# Altium schematic sheet MCU_RF.SchDoc
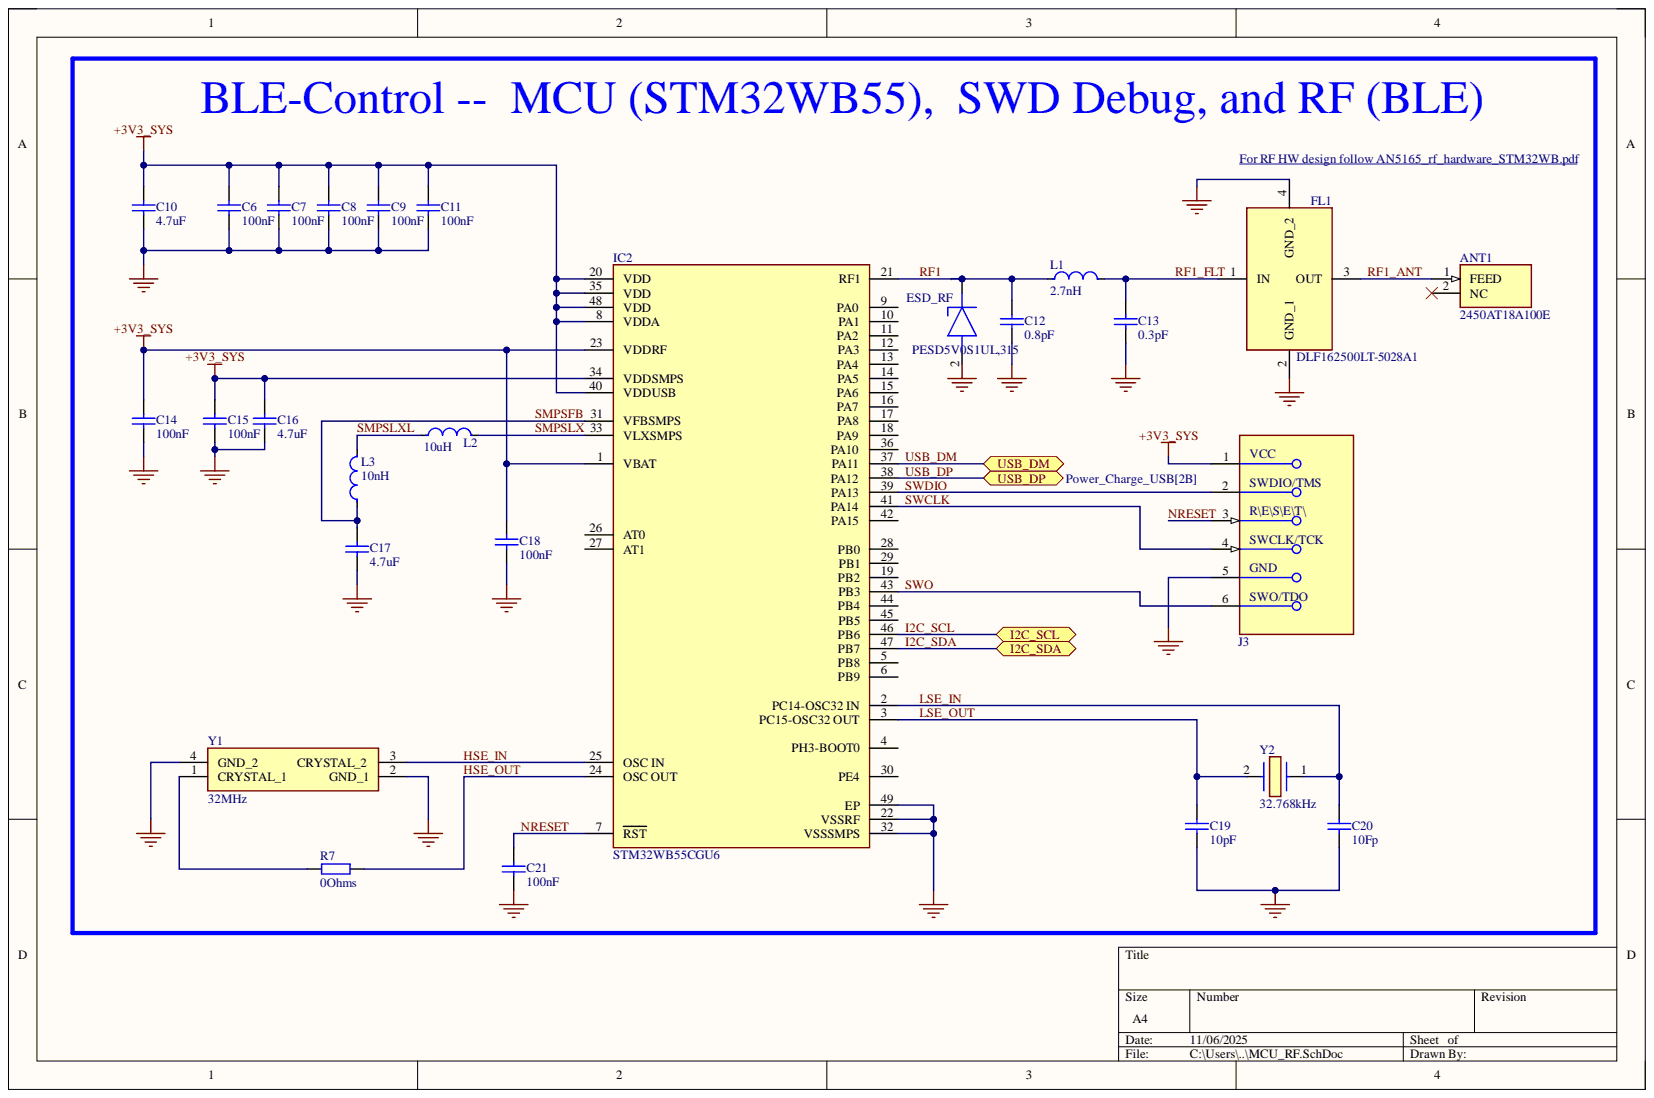
[diagram p0: MCU_RF.SchDoc]

## Parts
ANT1  2450AT18A100E  [ANTC3216X140N]
C6  100nF  [CAP_0402]
C7  100nF  [CAP_0402]
C8  100nF  [CAP_0402]
C9  100nF  [CAP_0402]
C10  4.7uF  [CAP_0402]
C11  100nF  [CAP_0402]
C12  0.8pF  [CAP_0402]
C13  0.3pF  [CAP_0402]
C14  100nF  [CAP_0402]
C15  100nF  [CAP_0402]
C16  4.7uF  [CAP_0402]
C17  4.7uF  [CAP_0402]
C18  100nF  [CAP_0402]
C19  10pF  [CAP_0402]
C20  10Fp  [CAP_0402]
C21  100nF  [CAP_0402]
ESD_RF  PESD5V0S1UL,315  [PESD5V0S1BL315]
FL1  DLF162500LT-5028A1  [DLF162500LT5028A1 - duplicate]
IC2  STM32WB55CGU6  [FP-STM32WB55CGU6-IPC_C]
J3  TC2030-CTX-NL  [Tag_Connect_0_MFG]  (value not drawn)
L1  2.7nH  [FP-LQW15AN_00-W0_6-0_1-MFG]
L2  10uH  [FP-LQM21FN_70-IPC_B]
L3  10nH  [FP-LQW15AN_00-W0_6-0_1-MFG]
R7  0Ohms  [RES_0402]
Y1  32MHz  [NX3225SA32MHZEXS00ACS02368]
Y2  32.768kHz  [ABS07]

## Ports
I2C_SCL, I2C_SDA, USB_DM, USB_DP

## Nets
+3V3_SYS: C6.2 C7.2 C8.2 C9.2 C10.2 C11.2 C14.2 C15.2 C16.2 C18.2 IC2.1(VBAT) IC2.8(VDDA) IC2.20(VDD) IC2.23(VDDRF) IC2.34(VDDSMPS) IC2.35(VDD) IC2.40(VDDUSB) IC2.48(VDD) J3.1
GND: C6.1 C7.1 C8.1 C9.1 C10.1 C11.1 C12.1 C13.1 C14.1 C15.1 C16.1 C17.1 C18.1 C19.1 C20.1 C21.1 ESD_RF.2 FL1.2(GND_1) FL1.4(GND_2) IC2.22(VSSRF) IC2.32(VSSSMPS) IC2.49(EP) J3.5 Y1.2(GND_1) Y1.4(GND_2)
HSE_IN: IC2.25(OSC_IN) Y1.3(CRYSTAL_2)
HSE_OUT: IC2.24(OSC_OUT) R7.2
I2C_SCL: IC2.46(PB6) ; port I2C_SCL
I2C_SDA: IC2.47(PB7) ; port I2C_SDA
LSE_IN: C20.2 IC2.2(PC14-OSC32_IN) Y2.1
LSE_OUT: C19.2 IC2.3(PC15-OSC32_OUT) Y2.2
NRESET: C21.2 IC2.7(RST) J3.3
RF1: C12.2 ESD_RF.1 IC2.21(RF1) L1.1
RF1_ANT: ANT1.1 FL1.3(OUT)
RF1_FLT: C13.2 FL1.1(IN) L1.2
SMPSFB: C17.2 IC2.31(VFBSMPS) L3.1
SMPSLX: IC2.33(VLXSMPS) L2.2
SMPSLXL: L2.1 L3.2
SWCLK: IC2.41(PA14) J3.4
SWDIO: IC2.39(PA13) J3.2
SWO: IC2.43(PB3) J3.6
USB_DM: IC2.37(PA11) ; port USB_DM
USB_DP: IC2.38(PA12) ; port USB_DP
NetR7_1: R7.1 Y1.1(CRYSTAL_1)

Not connected: ANT1 2; IC2 4(PH3-BOOT0) 5(PB8) 6(PB9) 9(PA0) 10(PA1) 11(PA2) 12(PA3) 13(PA4) 14(PA5) 15(PA6) 16(PA7) 17(PA8) 18(PA9) 19(PB2) 26(AT0) 27(AT1) 28(PB0) 29(PB1) 30(PE4) 36(PA10) 42(PA15) 44(PB4) 45(PB5)

## Library data of the parts
ANT1 = 2450AT18A100E: Antenna; Manufacturer_Name: JOHANSON TECHNOLOGY; Arrow Part Number: 2450AT18A100E; Arrow Price/Stock: https://www.arrow.com/en/products/2450at18a100e/johanson-dielectrics?utm_currency=USD&region=europe; Component Kind: Standard; Datasheet Link: https://www.johansontechnology.com/datasheets/2450AT18A100/2450AT18A100.pdf; Height: 1.4mm; Manufacturer_Part_Number: 2450AT18A100E; Mouser Part Number: 609-2450AT18A100E; Mouser Price/Stock: https://www.mouser.co.uk/ProductDetail/Johanson-Technology/2450AT18A100E?qs=yCnrNFeXz%252BjzOYKPg7LGCw%3D%3D
C6-C9 C11 C14 C15 C18-C21 = C_0402: Ceramic Chip Capacitor 0402; Dielectric: X7R; ProductionStatus: Placeholder; Tolerance: 10%; Voltage: 10V; Component Kind: Standard; Height: 0.35mm; Library Name: BLE-Control.SchLib; Package: 0402 (1005)
C10 C16 C17 = C_0402: Ceramic Chip Capacitor 0402; Part Number: C1005X5R1E105K; Dielectric: X7R; ProductionStatus: Placeholder; Tolerance: 10%; Voltage: 10V; Component Kind: Standard; Height: 0.35mm; Library Name: BLE-Control.SchLib; Package: 0402 (1005); PartNumber: C1005X5R1E105K
C12 = C_0402: GRM1555C1HR80BA01D; Dielectric: X7R; ProductionStatus: Placeholder; Tolerance: 10%; Voltage: 10V; Component Kind: Standard; Height: 0.35mm; Library Name: BLE-Control.SchLib; Package: 0402 (1005)
C13 = C_0402: GRM1555C1HR30WA01D; Dielectric: X7R; ProductionStatus: Placeholder; Tolerance: 10%; Voltage: 10V; Component Kind: Standard; Height: 0.35mm; Library Name: BLE-Control.SchLib; Package: 0402 (1005)
ESD_RF = PESD5V0S1UL,315: Diode ESD (Uni-directional); Manufacturer_Name: Nexperia; Arrow Part Number: PESD5V0S1UL,315; Arrow Price/Stock: https://www.arrow.com/en/products/pesd5v0s1ul315/nexperia?utm_currency=USD&region=nac; Component Kind: Standard; Datasheet Link: https://assets.nexperia.com/documents/data-sheet/PESD5V0S1UL.pdf; Height: 0mm; Manufacturer_Part_Number: PESD5V0S1UL,315; Mouser Part Number: 771-PESD5V0S1UL315; Mouser Price/Stock: https://www.mouser.co.uk/ProductDetail/Nexperia/PESD5V0S1UL315?qs=LOCUfHb8d9sSC%2FUB7ugsVw%3D%3D
FL1 = DLF162500LT-5028A1: Filter; Manufacturer_Name: TDK; Arrow Part Number: DLF162500LT-5028A1; Arrow Price/Stock: https://www.arrow.com/en/products/dlf162500lt-5028a1/tdk?utm_currency=USD&region=nac; Component Kind: Standard; Datasheet Link: https://product.tdk.com/system/files/dam/doc/product/rf/rf/filter/catalog/rf_lpf_dlf162500lt-5028a1_en.pdf; Height: 0.4mm; Library Name: BLE-Control.SchLib; Manufacturer_Part_Number: DLF162500LT-5028A1; Mouser Part Number: 810-DLF16250LT5028A1; Mouser Price/Stock: https://www.mouser.co.uk/ProductDetail/TDK/DLF162500LT-5028A1?qs=U%2FZX79kHR%2FlwIbAISCs3qA%3D%3D
IC2 = CMP-12105-000013-1: ULTRA-LOW-POWER DUAL CORE ARM CO; Manufacturer: STMicroelectronics; Core Architecture: ARM Cortex-M4; Data Bus Width: 32bits; Frequency: 64MHz; Interface: I2C,SPI,UART,USART,2-Wire,USB,IrDA,Other; Max Junction Temp: +105°C; Max Supply Voltage: 3.6V; Memory Size: 1MBytes; Memory Type: FLASH; Min Supply Voltage: 1.71V; Number Of A/D Converters: 1; Number Of Adc Channels: 13; Number Of I2C Channels: 2; Number Of I/Os: 30; Number Of Pwm Channels: 14; Number Of Timers/Counters: 6; Ram Size: 256KBytes; Standoff Height: 0mm; Ambient Temperature Range High: +85°C; Ambient Temperature Range Low: -40°C; Automotive: No; Category: IC; Component Kind: Standard; ComponentLink2Description: Datasheet; ComponentLink2URL: https://www.st.com/resource/en/datasheet/stm32wb55cg.pdf; Device Class L1: Integrated Circuits (ICs); Device Class L2: Embedded Processors and Controllers; Device Class L3: Microcontrollers; Digikey Description: ULTRA-LOW-POWER DUAL CORE ARM CO; Height: 0.65mm; Lead Free: Yes; Library Name: BLE-Control.SchLib; Manufacturer Part Number: STM32WB55CGU6; Number Of Cores: 1; Number Of Spi Channels: 1; Number Of Uart Channels: 2; Number Of Usb Channels: 1; Octopart: https://octopart.com/stm32wb55cgu6-stmicroelectronics-98750847; Package: UFQFPN48; RoHS: TRUE; Temperature: -40°C to +105°C; Temperature Range High: +105°C; Temperature Range Low: -40°C
L1 = CMP-06042-002082-1: Wire Wound RF Inductor 10nH ±2% 500mA 0.17Ω 0402 (1005); Core Material: Non-Magnetic; Current: 370mA; DC Resistance (DCR): 170mR; Self Resonant Frequency: 5.5GHz; Shielding: Unshielded; Test Frequency: 100MHz; Case Code (Imperial): 0402; Case Code (Metric): 1005; Case/Package: 0402; Component Kind: Standard; Current Rating: 500mA; DC Current: 500mA; Depth: 0.7mm; Height: 0.5mm; Height - Seated (Max): 0.024inch; Inductance: 10nH; Inductance Tolerance: 2%; Lead Free: Lead Free; Length: 1mm; Library Name: BLE-Control.SchLib; Max DC Current: 500mA; Max Operating Temperature: 125°C; Military Standard: Not; Min Operating Temperature: -55°C; Mount: Surface Mount; Number of Pins: 2; Package Quantity: 10000; Packaging: Tape and Reel; Radiation Hardening: No; REACH SVHC: No SVHC; Resistor Type: Wirewound; Termination: SMD/SMT; Tolerance: 2%; Weight: 2.8E-05oz; Width: 0.02inch
L2 = CMP-2000-06587-2: FIXED IND 10UH 100MA 780MOHM SMD; Core Material: Ferrite; DC Resistance (DCR): 780mR; Self Resonant Frequency: 15MHz; Shielding: Shielded; Test Frequency: 1MHz; Tolerance: 20%; Case Code (Imperial): 0805; Case Code (Metric): 2012; Case/Package: 0805; Component Kind: Standard; Current Rating: 100mA; DC Current: 100mA; Depth: 1.45mm; Height: 1.45mm; Height - Seated (Max): 0.057inch; Inductance: 10uH; Lead Free: Lead Free; Length: 2.2mm; Library Name: BLE-Control.SchLib; Max DC Current: 100mA; Max Operating Temperature: 125°C; Military Standard: Not; Min Operating Temperature: -55°C; Mount: Surface Mount; Package Quantity: 3000; Packaging: Tape and Reel; Radiation Hardening: No; Rated Current-Max: 100mA; RoHS Compliant: Yes; Saturation Current: 100mA; Series: LQM21F_70; Termination: SMD/SMT; Width: 0.049inch
L3 = CMP-06042-002082-1: LQG15HS2N7S02; Core Material: Non-Magnetic; Current: 370mA; Current Rating: 500mA; DC Resistance (DCR): 170mR; Inductance Tolerance: 2%; Self Resonant Frequency: 5.5GHz; Shielding: Unshielded; Test Frequency: 100MHz; Case Code (Imperial): 0402; Case Code (Metric): 1005; Case/Package: 0402; Component Kind: Standard; DC Current: 500mA; Depth: 0.7mm; Height: 0.5mm; Height - Seated (Max): 0.024inch; Inductance: 10nH; Lead Free: Lead Free; Length: 1mm; Library Name: BLE-Control.SchLib; Max DC Current: 500mA; Max Operating Temperature: 125°C; Military Standard: Not; Min Operating Temperature: -55°C; Mount: Surface Mount; Number of Pins: 2; Package Quantity: 10000; Packaging: Tape and Reel; Radiation Hardening: No; REACH SVHC: No SVHC; Resistor Type: Wirewound; Termination: SMD/SMT; Tolerance: 2%; Weight: 2.8E-05oz; Width: 0.02inch
R7 = R_0402: Resistor SMD 0402; Component Kind: Standard; Library Name: BLE-Control.SchLib
Y1 = NX3225SA-32MHZ-EXS00A-CS02368: Crystal or Oscillator; Manufacturer_Name: NDK; Component Kind: Standard; Datasheet Link: https://hu.mouser.com/datasheet/2/905/ndk_04232018_NX3225SA-32MHZ-EXS00A-CS02368-1324924.pdf; Height: 0.6mm; Manufacturer_Part_Number: NX3225SA-32MHZ-EXS00A-CS02368; Mouser Part Number: 344-NX3225SA32MHZEXS; Mouser Price/Stock: https://www.mouser.co.uk/ProductDetail/NDK/NX3225SA-32MHZ-EXS00A-CS02368?qs=55YtniHzbhCu90OOsHDzwg%3D%3D
Y2 = ABS07-32.768KHZ-7-T: Crystal or Oscillator; Manufacturer_Name: ABRACON; Arrow Part Number: ABS07-32.768KHZ-7-T; Arrow Price/Stock: https://www.arrow.com/en/products/abs07-32.768khz-7-t/abracon?utm_currency=USD&region=europe; Component Kind: Standard; Datasheet Link: https://abracon.com/Resonators/ABS07.pdf; Height: 0.9mm; Manufacturer_Part_Number: ABS07-32.768KHZ-7-T; Mouser Part Number: 815-ABS07-32.768K7T; Mouser Price/Stock: https://www.mouser.co.uk/ProductDetail/ABRACON/ABS07-32.768KHZ-7-T?qs=2nyfZ6BV3oibNEFok2qBLA%3D%3D
J3 = TC2030-CTX-NL: Component Kind: Standard; Library Name: BLE-Control.SchLib
